# Altium schematic sheet 2510_RegulationChauffage_Daugtherboard.SchDoc
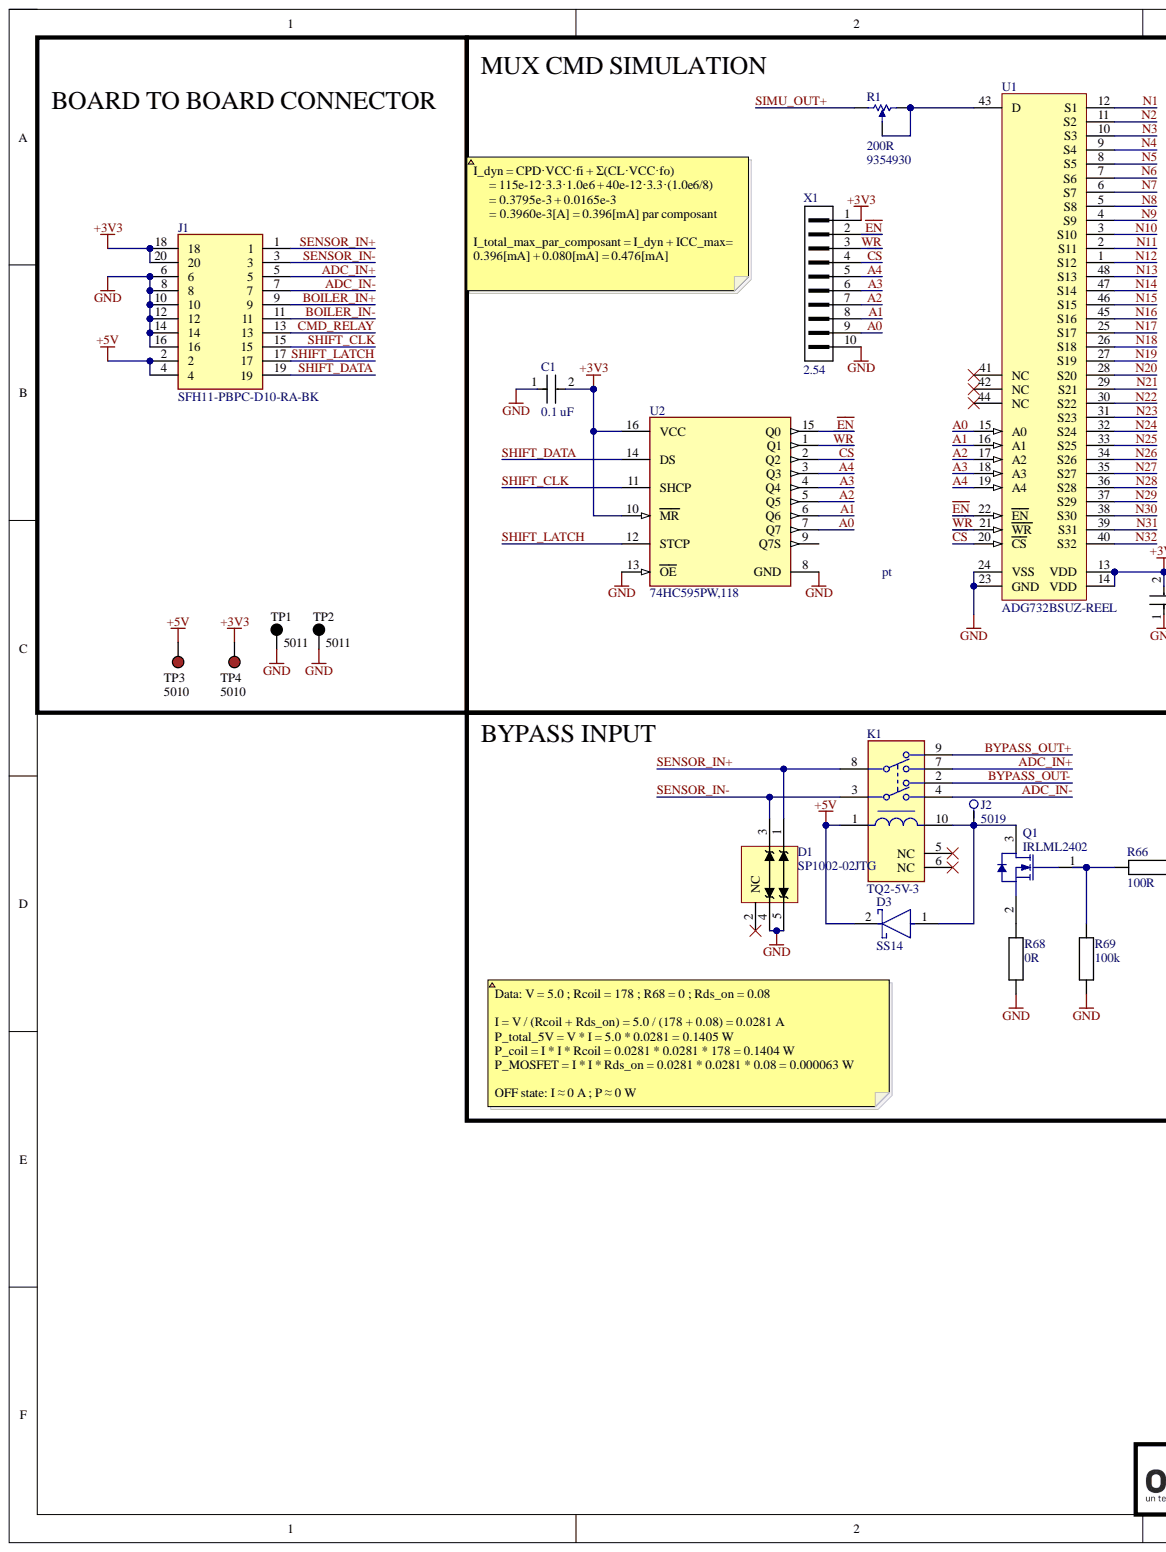
[diagram p0: 2510_RegulationChauffage_Daugtherboard.SchDoc, left]
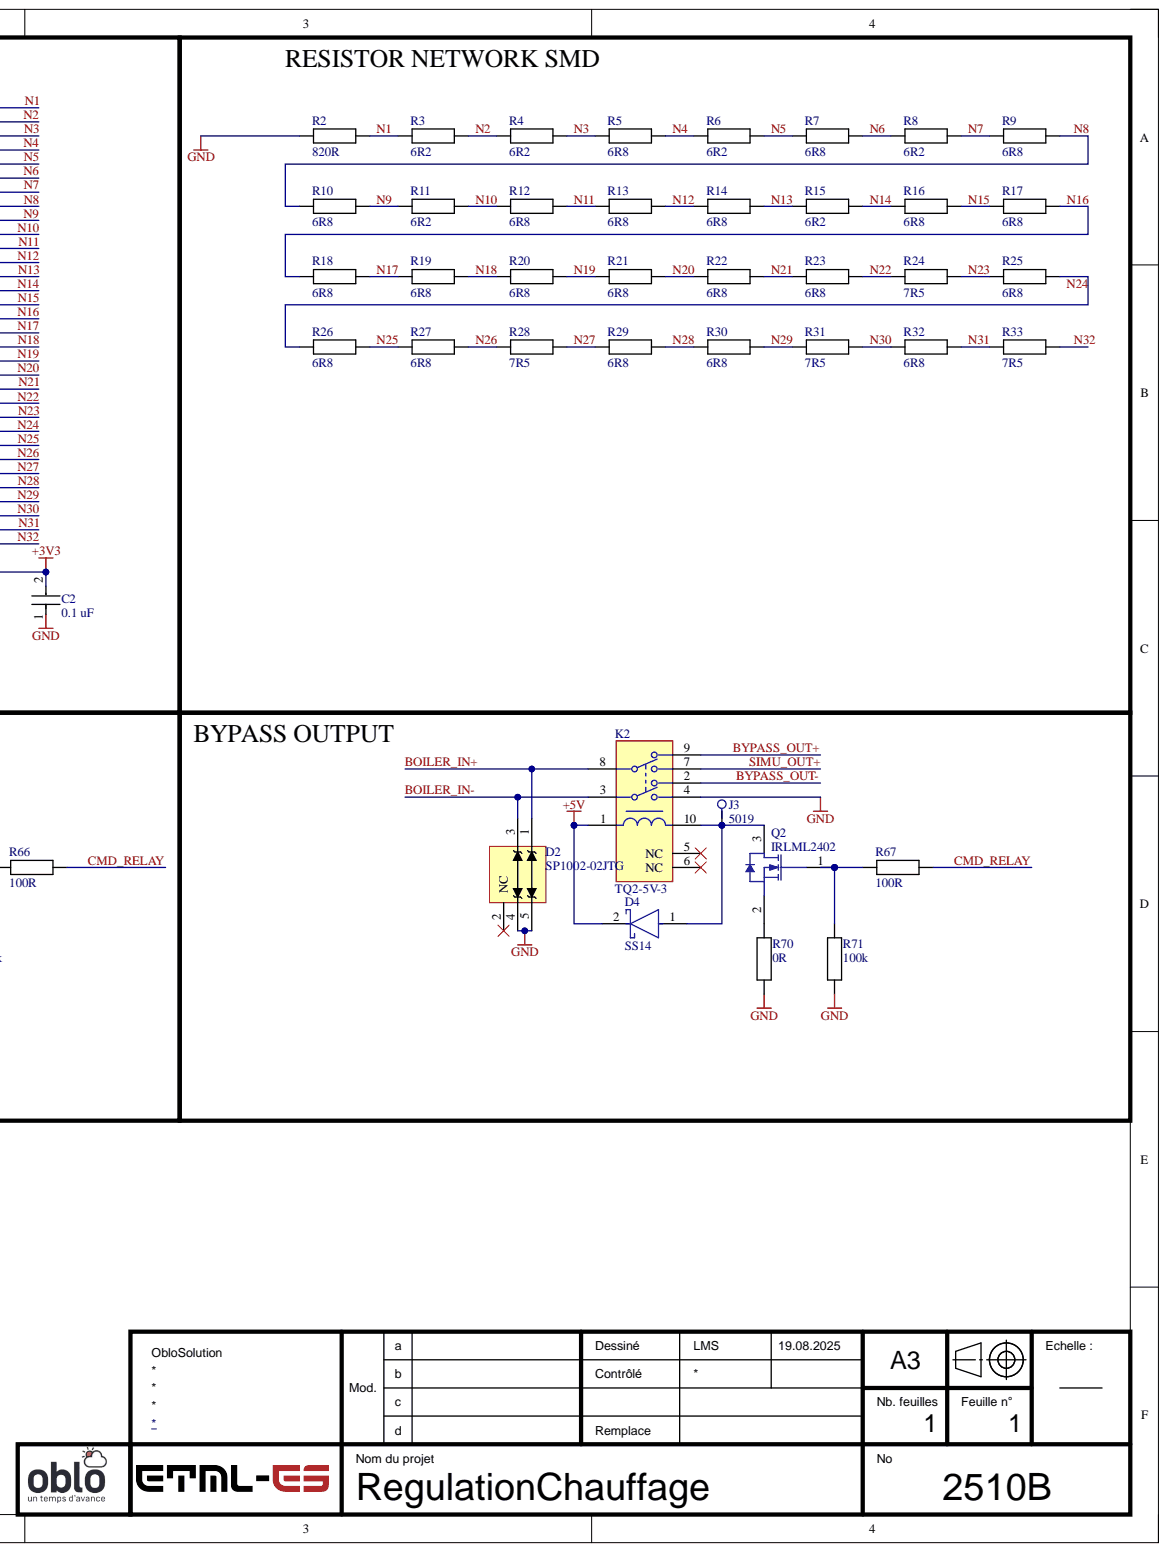
[diagram p1: 2510_RegulationChauffage_Daugtherboard.SchDoc, right]

## Parts
C1  0.1 uF  Tolerance: 0.1  [FP-CC0805-0_85-IPC_C]
C2  0.1 uF  Tolerance: 0.1  [FP-CC0805-0_85-IPC_C]
D1  SP1002-02JTG  [SOT65P220X110-5N]
D2  SP1002-02JTG  [SOT65P220X110-5N]
D3  SS14  [FP-403AE-MFG]
D4  SS14  [FP-403AE-MFG]
J1  SFH11-PBPC-D10-RA-BK  [CONN20_D10-RA-BK_SUL]
J2  5019  [FP-5019-MFG]
J3  5019  [FP-5019-MFG]
K1  TQ2-5V-3  [FP-TQ2-5V-3-MFG]
K2  TQ2-5V-3  [FP-TQ2-5V-3-MFG]
Q1  IRLML2402  [SOT95P237X112-3M]
Q2  IRLML2402  [SOT95P237X112-3M]
R1  200R  Supplier Part Number 1: 9354930  [BOUR-3386H]
R2  820R  [THT SMD resistor]
R3  6R2  [THT SMD resistor]
R4  6R2  [THT SMD resistor]
R5  6R8  [THT SMD resistor]
R6  6R2  [THT SMD resistor]
R7  6R8  [THT SMD resistor]
R8  6R2  [THT SMD resistor]
R9  6R8  [THT SMD resistor]
R10  6R8  [THT SMD resistor]
R11  6R2  [THT SMD resistor]
R12  6R8  [THT SMD resistor]
R13  6R8  [THT SMD resistor]
R14  6R8  [THT SMD resistor]
R15  6R2  [THT SMD resistor]
R16  6R8  [THT SMD resistor]
R17  6R8  [THT SMD resistor]
R18  6R8  [THT SMD resistor]
R19  6R8  [THT SMD resistor]
R20  6R8  [THT SMD resistor]
R21  6R8  [THT SMD resistor]
R22  6R8  [THT SMD resistor]
R23  6R8  [THT SMD resistor]
R24  7R5  [THT SMD resistor]
R25  6R8  [THT SMD resistor]
R26  6R8  [THT SMD resistor]
R27  6R8  [THT SMD resistor]
R28  7R5  [THT SMD resistor]
R29  6R8  [THT SMD resistor]
R30  6R8  [THT SMD resistor]
R31  7R5  [THT SMD resistor]
R32  6R8  [THT SMD resistor]
R33  7R5  [THT SMD resistor]
R66  100R  [RESC2012X06M]
R67  100R  [RESC2012X06M]
R68  0R  [RESC2012X06M]
R69  100k  [RESC2012X06M]
R70  0R  [RESC2012X06M]
R71  100k  [RESC2012X06M]
TP1  5011  [KSTN-5011_V]
TP2  5011  [KSTN-5011_V]
TP3  5010  [KSTN-5010_V]
TP4  5010  [KSTN-5010_V]
U1  ADG732BSUZ-REEL  [ADI-SU-48_L]
U2  74HC595PW,118  [FP-SOT403-1-IPC_A]
X1  2.54  [PIN-1X10-2.54]

## Nets
+3V3: C1.2 C2.2 J1.18 J1.20 TP4.1 U1.13(VDD) U1.14(VDD) U2.10(MR) U2.16(VCC) X1.1
+5V: D3.2 D4.2 J1.2 J1.4 K1.1 K2.1 TP3.1
GND: C1.1 C2.1 D1.4 D1.5 D2.4 D2.5 J1.6 J1.8 J1.10 J1.12 J1.14 J1.16 K2.4 R2.1 R68.1 R69.2 R70.1 R71.2 TP1.1 TP2.1 U1.23(GND) U1.24(VSS) U2.8(GND) U2.13(OE) X1.10
A0: U1.15(A0) U2.7(Q7) X1.9
A1: U1.16(A1) U2.6(Q6) X1.8
A2: U1.17(A2) U2.5(Q5) X1.7
A3: U1.18(A3) U2.4(Q4) X1.6
A4: U1.19(A4) U2.3(Q3) X1.5
ADC_IN+: J1.5 K1.7
ADC_IN-: J1.7 K1.4
BOILER_IN+: D2.1 J1.9 K2.8
BOILER_IN-: D2.3 J1.11 K2.3
BYPASS_OUT+: K1.9 K2.9
BYPASS_OUT-: K1.2 K2.2
~{CS}: U1.20(CS) U2.2(Q2) X1.4
CMD_RELAY: J1.13 R66.1 R67.1
~{EN}: U1.22(EN) U2.15(Q0) X1.2
N1: R2.2 R3.1 U1.12(S1)
N2: R3.2 R4.1 U1.11(S2)
N3: R4.2 R5.1 U1.10(S3)
N4: R5.2 R6.1 U1.9(S4)
N5: R6.2 R7.1 U1.8(S5)
N6: R7.2 R8.1 U1.7(S6)
N7: R8.2 R9.1 U1.6(S7)
N8: R9.2 R10.1 U1.5(S8)
N9: R10.2 R11.1 U1.4(S9)
N10: R11.2 R12.1 U1.3(S10)
N11: R12.2 R13.1 U1.2(S11)
N12: R13.2 R14.1 U1.1(S12)
N13: R14.2 R15.1 U1.48(S13)
N14: R15.2 R16.1 U1.47(S14)
N15: R16.2 R17.1 U1.46(S15)
N16: R17.2 R18.1 U1.45(S16)
N17: R18.2 R19.1 U1.25(S17)
N18: R19.2 R20.1 U1.26(S18)
N19: R20.2 R21.1 U1.27(S19)
N20: R21.2 R22.1 U1.28(S20)
N21: R22.2 R23.1 U1.29(S21)
N22: R23.2 R24.1 U1.30(S22)
N23: R24.2 R25.1 U1.31(S23)
N24: R25.2 R26.1 U1.32(S24)
N25: R26.2 R27.1 U1.33(S25)
N26: R27.2 R28.1 U1.34(S26)
N27: R28.2 R29.1 U1.35(S27)
N28: R29.2 R30.1 U1.36(S28)
N29: R30.2 R31.1 U1.37(S29)
N30: R31.2 R32.1 U1.38(S30)
N31: R32.2 R33.1 U1.39(S31)
N32: R33.2 U1.40(S32)
SENSOR_IN+: D1.1 J1.1 K1.8
SENSOR_IN-: D1.3 J1.3 K1.3
SHIFT_CLK: J1.15 U2.11(SHCP)
SHIFT_DATA: J1.19 U2.14(DS)
SHIFT_LATCH: J1.17 U2.12(STCP)
SIMU_OUT+: K2.7 R1.1
~{WR}: U1.21(WR) U2.1(Q1) X1.3
NetD3_1: D3.1 J2.1 K1.10 Q1.3
NetD4_1: D4.1 J3.1 K2.10 Q2.3
NetQ1_1: Q1.1 R66.2 R69.1
NetQ1_2: Q1.2 R68.2
NetQ2_1: Q2.1 R67.2 R71.1
NetQ2_2: Q2.2 R70.2
NetR1_2: R1.2 R1.3 U1.43(D)

Not connected: D1 2(NC); D2 2(NC); K1 5(NC) 6(NC); K2 5(NC) 6(NC); U1 41(NC) 42(NC) 44(NC); U2 9(Q7S)

## Library data of the parts
C1 C2 = CAP CER 0.1 uF: CAP CER 0.1 uF 10V X5R 0805; Manufacturer: Yageo; Manufacturer Part Number: CC0805KKX5R6BB475; Material: Ceramic; Automotive: No; Capacitance: 0.1 uF; Category: Cap; Component Kind: Standard; ComponentLink2Description: Datasheet; ComponentLink2URL: http://www.yageo.com/documents/recent/UPY-GPHC_Y5V_6.3V-to-50V_8.pdf; Device Class L1: Passive Components; Device Class L2: Capacitors; Device Class L3: Ceramic Capacitors; Digikey Description: CAP CER 4.7UF 10V X5R 0805; Footprint Url: http://www.yageo.com/exep/pages/download/literatures/UPY-C_GEN_24.pdf; Height: 0.95mm; Ipc Land Pattern Name: CAPC200125X85; Lead Free: Yes; Mouser Description: Multilayer Ceramic Capacitors MLCC - SMD/SMT 4.7uF 10V X5R 10%; Octopart: https://octopart.com/cc0805kkx5r6bb475-yageo-42244641; Package: CC0805-0.85; RoHS: TRUE; Supplier 1: Farnell; Supplier Part Number 1: 3019949; Temperature: -30°C to +85°C; Temperature Characteristic: X5R; Temperature Range High: +85°C; Temperature Range Low: -30°C; Value: 0.1 uF; Voltage Rating: 10 V; Library Name: 2510_RegulationChauffage_MotherBoard.SchLib
D1 D2 = SP1002-02JTG: 16V Pince 2 A (8/20µs) Ipp TVS - Diodes Montage en surface SC-70-5; Component Kind: Standard; Supplier 1: Digikey; Supplier Part Number 1: F4238CT-ND; Library Name: 2510_RegulationChauffage_MotherBoard.SchLib
D3 D4 = SS14: DIODE SCHOTTKY 40V 1A SMA; Manufacturer: ON Semiconductor; Max Junction Temp: +125°C; Max Surge Forward Current: 40A; Peak Reverse Current: 0.2mA; Standoff Height: 0.05mm; Automotive: No; Category: Diode; Component Kind: Standard; ComponentLink2Description: Datasheet; ComponentLink2URL: https://www.onsemi.com/pub/Collateral/SS19-D.PDF; Device Class L1: Discrete Semiconductors; Device Class L2: Diodes; Device Class L3: Schottky Diodes; Digikey Description: DIODE SCHOTTKY 40V 1A SMA; Forward Current: 1A; Height: 2.5mm; Lead Free: Yes; Manufacturer Part Number: SS14; Max Repetitive Reverse Voltage: 40V; Mouser Description: Schottky Diodes & Rectifiers SMA 40V 1.0A; Octopart: https://octopart.com/ss14-on+semiconductor-29205033; Package: 403AE; RoHS: TRUE; Supplier 1: Farnell; Supplier Part Number 1: 1467537; Temperature: -65°C to +125°C; Temperature Range High: +125°C; Temperature Range Low: -65°C; Library Name: 2510_RegulationChauffage_MotherBoard.SchLib
J1 = SFH11-PBPC-D10-RA-BK: No Description Available; Manufacturer_Name: Sullins; Component Kind: Standard; Manufacturer_Part_Number: SFH11-PBPC-D10-RA-BK; RefDes: RefDes; Type: Type; Copyright: Copyright (C) 2025 Ultra Librarian. All rights reserved.; Library Name: 2510_RegulationChauffage_MotherBoard.SchLib
J2 J3 = 5019: NexarPartId: 22052288; Number of Contacts: 1; Component Kind: Standard; Contact Material: Bronze, Silver; Contact Plating: Silver; Height: 2.286mm; Lead Free: Lead Free; Material: Bronze; Mount: Surface Mount; Number of Pins: 1; Packaging: Tape and Reel; REACH SVHC: No SVHC; RoHS: Compliant; Supplier 1: Digikey; Supplier Part Number 1: 36-5019CT-ND; Termination: SMD/SMT; Library Name: 2510_RegulationChauffage_MotherBoard.SchLib
K1 K2 = CMP-05607-000142-1: RELAY TELECOM DPDT 1A 5VDC; Coil Current: 28.1mA; Coil Power: 140mW; Coil Resistance: 178R; Coil Type: Non-Latching; Coil Voltage (DC): 5V; Insertion Loss: 0.6dB; Max Voltage Rating (AC): 125V; Max Voltage Rating (DC): 110V; Operate Time: 3ms; Case/Package: DIP; Component Kind: Standard; Contact Current Rating: 1A; Contact Material: Gold; Depth: 9mm; Lead Free: Lead Free; Length: 14mm; Library Name: Altium Content Vault; Max Current Rating: 1A; Max Operating Temperature: 70°C; Min Operating Temperature: -40°C; Mount: Through Hole; Number of Pins: 8; Package Quantity: 50; Packaging: Rail/Tube; Power Consumption: 140mW; Relay Type: Telecom; Release Time: 3ms; RoHS Compliant: Yes; Series: TQ; Termination: PC Pin; Throw Configuration: DPDT; Voltage Rating (AC): 125V; Weight: 1.5g
R1 = 3386H-1-201LF: 200 Ohms 0.5W, 1/2W PC Pins Through Hole Trimmer Potentiometer Cermet 1.0 Turn Side Adjustment; Manufacturer: Bourns; Part No: 3386H-1-501LF; COO: CR; Linear Or Logarithmic: Lin; Number of Turns: 1(Elec),1(Mech)Turns; Power Rating: 0.5(1/2)W; Product Weight: 0.85g; Resistance Value: 500Ohm; Shaft Diameter: 3.15mm; Temperature Coefficient: 100ppm/C; Tolerance: 10%; Component Kind: Standard; Datasheet URL: http://www.bourns.com/docs/Product-Datasheets/3386.pdf; Datasheet Version: 05/2016; Dimension: 9.53x4.83x9.53mm; ECCN: EAR99; Failure Rate: N/R; Family: 3386; HTS: 8533408050; Manufacturer URL: http://www.bourns.com/; Military Standard: N/R; Mounting: ThroughHole; Mounting Technology: ThroughHole; Operating Temperature: -55to125C; Package Description: 3-Pin Through Hole Device, Body 9.53 x 4.83 mm, Pitch 2.54 mm; Package Reference: 3386H; Packaging: Tube; RoHS: Yes; Supplier: Bourns; Supplier 1: Farnell; Supplier Standard Pack: 50; Technology: Cermet; Termination Style: Pin; Type: Trimmer; Library Name: 2510_RegulationChauffage_MotherBoard.SchLib
R2 = RES 820 OHM 1% 1/8W 0805: 820 Ohms ±1% 0,125W, 1/8W Pavé résistif 0805 (2012 métrique) Couche épaisse - RC0805FR-13820RL; Component Kind: Standard; Supplier 1: Digikey; Supplier Part Number 1: 13-RC0805FR-13820RLCT-ND; Library Name: 2510_RegulationChauffage_MotherBoard.SchLib
R3 R4 R6 R8 R11 R15 = RES 6.2 OHM 1% 1/8W 0805_1: RES 6.2 OHM 1% 1/8W 0805 RC0805FR-076R2L; Component Kind: Standard; Supplier 1: Farnell; Supplier Part Number 1: 3952295; Library Name: 2510_RegulationChauffage_MotherBoard.SchLib
R5 R7 R9 R10 R12-R14 R16-R23 R25-R27 R29 R30 R32 = RES 6.8 OHM 1% 1/8W 0805: Component Kind: Standard; Description: RES 6.8 OHM 1% 1/8W 0805; Supplier 1: Digikey; Supplier Part Number 1: 311-6.80CRCT-ND; Library Name: 2510_RegulationChauffage_MotherBoard.SchLib
R24 R28 R31 R33 = RES SMD 7.5 OHM 1% 1/8W 0805: RES SMD 7.5 OHM 1% 1/8W 0805 AC0805FR-077R5L; Component Kind: Standard; Supplier 1: Digikey; Supplier Part Number 1: 13-AC0805FR-077R5LCT-ND; Library Name: 2510_RegulationChauffage_MotherBoard.SchLib
R66 R67 = CMP-066-000175-2: RES 100ohm  ±1% 0.125W 2012 (0805 INCH); Manufacturer: Yageo; Manufacturer Number: RC0805FR-07100RL; IsStocked: 1; PN: PN-217910; TemperatureCoeff: ±200ppm/°C; TemperatureRange: -55°C to 155°C; Value: 100; Voltage: 150V; Category: Passives; Component Kind: Standard; Library Name: ETML-ES; Power: 0.125W; SubCategory: Resistances couche epaisse; Supplier 1: ETML; Supplier Part Number 1: FAB.0805_R 100R; Supplier Price 1: 0.0200; Tolerance: ±1%
R68 R70 = CMP-066-000150-2: RES 0ohm  ±1% 0.125W 2012 (0805 INCH); Manufacturer: Vishay; Manufacturer Number: CRCW08050000Z0EA; IsStocked: 1; PN: PN-391869; TemperatureCoeff: ±200ppm/°C; TemperatureRange: -55°C to 155°C; Value: 0; Voltage: 150V; Category: Passives; Component Kind: Standard; Library Name: ETML-ES; Power: 0.125W; SubCategory: Resistances couche epaisse; Supplier 1: ETML; Supplier Part Number 1: FAB.0805_R 0R; Supplier Price 1: 0.0200; Tolerance: ±1%
R69 R71 = CMP-066-000211-2: RES 100kohm  ±1% 0.125W 2012 (0805 INCH); Manufacturer: Yageo; Manufacturer Number: RC0805FR-07100KL; IsStocked: 1; PN: PN-903408; TemperatureCoeff: ±200ppm/°C; TemperatureRange: -55°C to 155°C; Voltage: 150V; Category: Passives; Component Kind: Standard; Library Name: ETML-ES; Power: 0.125W; SubCategory: Resistances couche epaisse; Supplier 1: ETML; Supplier Part Number 1: FAB.0805_R 100k; Supplier Price 1: 0.0200; Tolerance: ±1%; Value: 100k
TP1 TP2 = 5011: Test Point, Black, Through Hole, RoHS, Bulk; Manufacturer: Keystone; Colour: Black; Component Kind: Standard; ComponentLink1Description: Manufacturer URL; ComponentLink1URL: http://www.keyelco.com/; ComponentLink2Description: Datasheet; ComponentLink2URL: http://www.keyelco.com/product-pdf.cfm?p=1320; Contact Material: Bronze; Contact Plating: Silver; DatasheetVersion: Rev. F, 12/2013; Height: 8.12 mm; Mount: Through Hole; Mounting Technology: Through Hole; Package Quantity: 100; PackageDescription: 1-Pin Through Hole Device, Dia 3.17 mm; PackageReference: 5011; Packaging: Bulk; Pins: 1; REACH SVHC: No SVHC; RoHSCompliant: true; Library Name: 2510_RegulationChauffage_MotherBoard.SchLib
TP3 TP4 = 5010: Test Point, Red, Through Hole, RoHS, Bulk; Manufacturer: Keystone; Colour: Red; Component Kind: Standard; ComponentLink1Description: Manufacturer URL; ComponentLink1URL: http://www.keyelco.com/; ComponentLink2Description: Datasheet; ComponentLink2URL: http://www.keyelco.com/product-pdf.cfm?p=1319; Contact Material: Bronze; Contact Plating: Silver; DatasheetVersion: Rev. F, 12/2013; Height: 8.12 mm; Mount: Through Hole; Mounting Technology: Through Hole; Package Quantity: 100; PackageDescription: 1-Pin Through Hole Device, Dia 3.17 mm; PackageReference: 5010; Packaging: Bulk; Pins: 1; REACH SVHC: No SVHC; RoHSCompliant: true; Library Name: 2510_RegulationChauffage_MotherBoard.SchLib
U1 = ADG732BSUZ-REEL: 1.8 to 5.5 V / +/-2.5 V, 4 Ohm, 32-Channel Analog Multiplexer, -40 to 85 degC, 48-pin QFP (SU-48), Reel; Manufacturer: Analog Devices; Bandwidth (Hz): 18M; Max Analog Signal Range: Vss to Vdd; Ron (ohms): 4; Supply V Guar Performance: Dual_(+/-2.5V), Single_(+3V), Single_(+5V); t transition (s): 23n; Code_JEDEC: MS-026ABC; Component Kind: Standard; ComponentLink1Description: Manufacturer URL; ComponentLink1URL: http://www.analog.com/en/index.html; ComponentLink2Description: Datasheet; ComponentLink2URL: http://www.analog.com/static/imported-files/data_sheets/ADG726_732.pdf; ComponentLink3Description: Package Specification; ComponentLink3URL: http://www.analog.com/static/imported-files/packages/470644706845302410119987SU48_7x7.pdf; DatasheetVersion: Rev. 0; Mounting Technology: Surface Mount; PackageDescription: 48-Pin Thin Plastic Quad Flat Package, Body 7 x 7 mm, Pitch 0.5 mm; PackageReference: SU-48; Packing: Reel; PartNumber: ADG732BSUZ-REEL; Library Name: 2510_RegulationChauffage_MotherBoard.SchLib
U2 = 74HC595PW,118: IC SHIFT REGISTER 8BIT 16TSSOP; Component Kind: Standard; Library Name: 2510_RegulationChauffage_MotherBoard.SchLib
X1 = CMP-055-000013-1: PIN HEADER 2.54 1x10; Manufacturer: Würth Electronik; Manufacturer Number: 61300411121; Current: 3A; IsStocked: True; PN: PN-161861; TemperatureRange: -40°C to +105°C; Voltage: 250V; Category: Connectors; Component Kind: Standard; Library Name: ETML-ES; SubCategory: Pin Header; Value: 2.54
Q1 Q2 = IRLML2402: Component Kind: Standard; Supplier 1: Mouser; Supplier Part Number 1: 942-IRLML2402TRPBF; Library Name: 2510_RegulationChauffage_MotherBoard.SchLib
